# Altium schematic sheet 243-436_Manette-ESP32.SchDoc(243-436_Manette-ESP32)
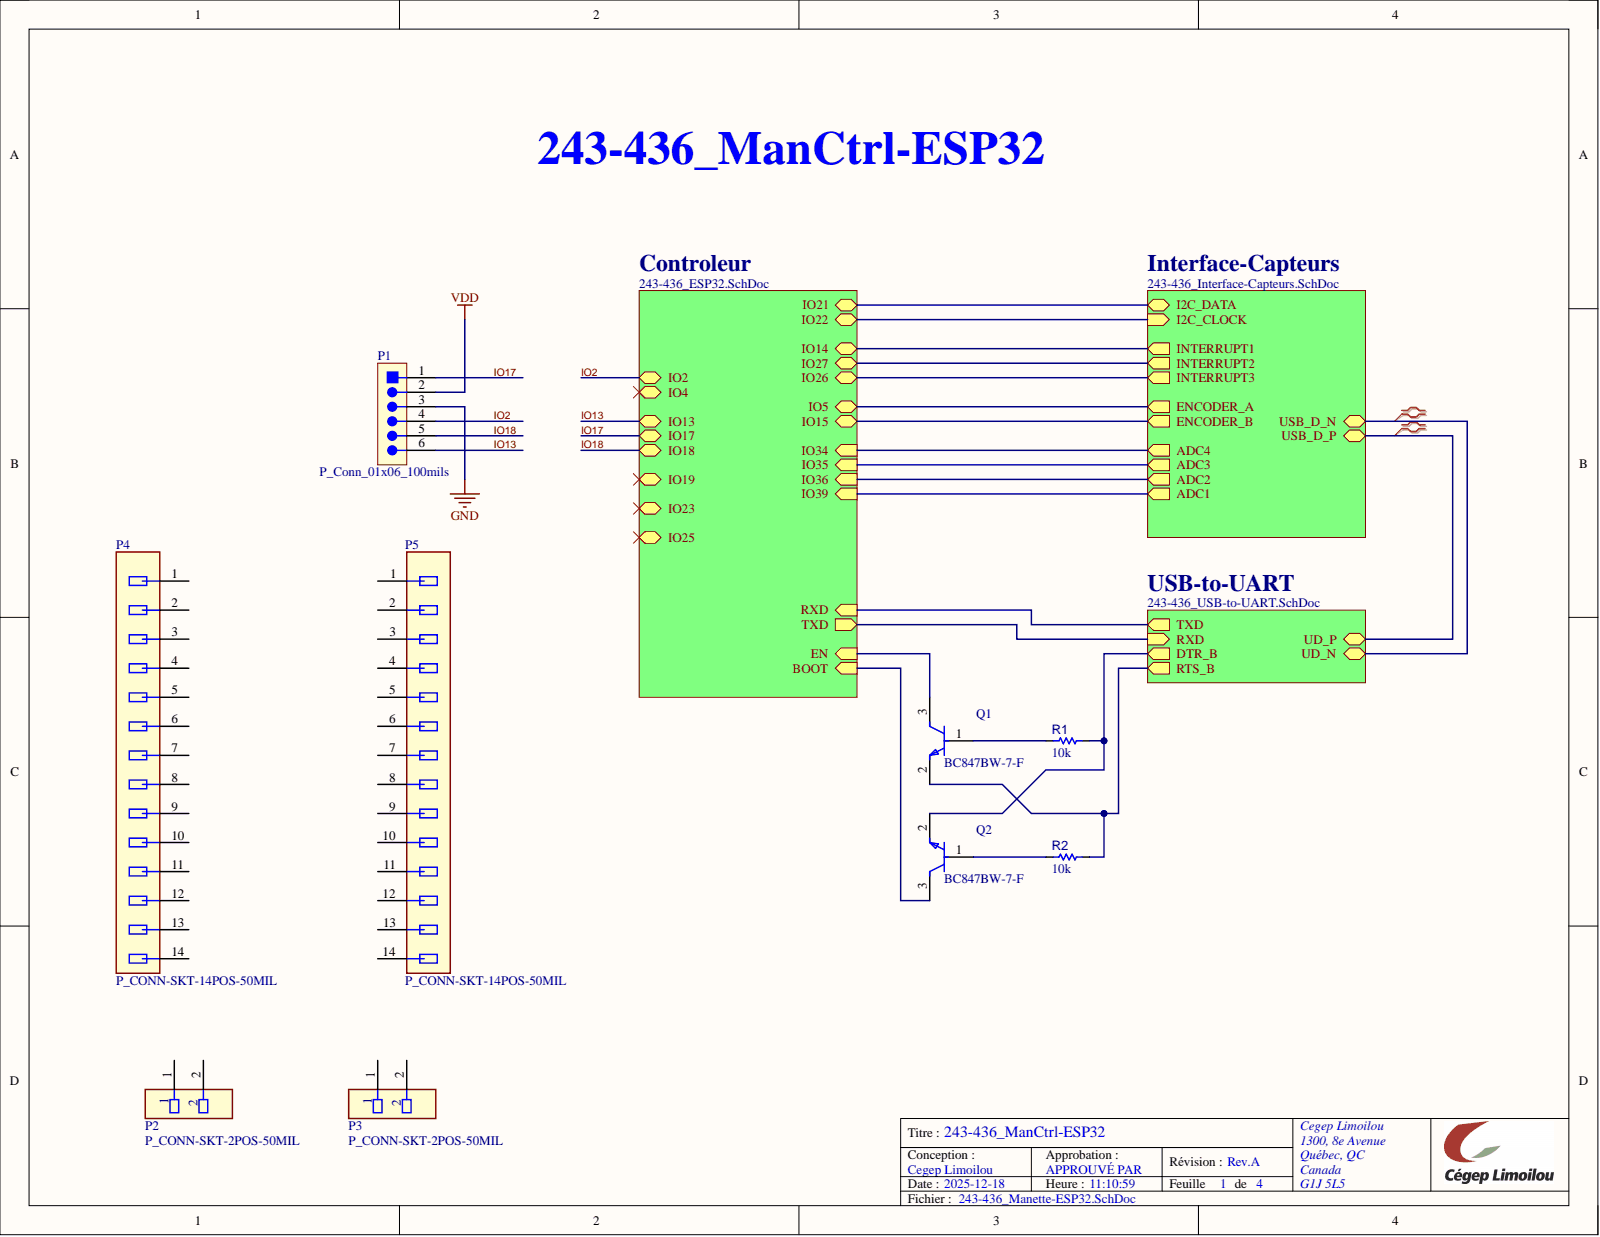
[diagram p0: 243-436_Manette-ESP32.SchDoc]

## Parts
P1  P_Conn_01x06_100mils  [P_CONN_HDR_1ROW_6POS_100MIL]  (no BOM)
P2  P_CONN-SKT-2POS-50MIL  [P_CONN_SKT_1ROW_2POS_50MIL]
P3  P_CONN-SKT-2POS-50MIL  [P_CONN_SKT_1ROW_2POS_50MIL]
P4  P_CONN-SKT-14POS-50MIL  [P_CONN_SKT_1ROW_14POS_50MIL]
P5  P_CONN-SKT-14POS-50MIL  [P_CONN_SKT_1ROW_14POS_50MIL]
Q1  BC847BW-7-F  [FP-SOT323-MFG]
Q2  BC847BW-7-F  [FP-SOT323-MFG]
R1  value 10k  [R_1005[0402]]  (hidden comment: Res 10k 1% 0402)
R2  value 10k  [R_1005[0402]]  (hidden comment: Res 10k 1% 0402)

## Nets
GND: P1.3
VDD: P1.2
IO2: P1.4
IO13: P1.6
IO17: P1.1
IO18: P1.5
NetP2_1: P2.1
NetP2_2: P2.2
NetP3_1: P3.1
NetP3_2: P3.2
NetP4_1: P4.1
NetP4_2: P4.2
NetP4_3: P4.3
NetP4_4: P4.4
NetP4_5: P4.5
NetP4_6: P4.6
NetP4_7: P4.7
NetP4_8: P4.8
NetP4_9: P4.9
NetP4_10: P4.10
NetP4_11: P4.11
NetP4_12: P4.12
NetP4_13: P4.13
NetP4_14: P4.14
NetP5_1: P5.1
NetP5_2: P5.2
NetP5_3: P5.3
NetP5_4: P5.4
NetP5_5: P5.5
NetP5_6: P5.6
NetP5_7: P5.7
NetP5_8: P5.8
NetP5_9: P5.9
NetP5_10: P5.10
NetP5_11: P5.11
NetP5_12: P5.12
NetP5_13: P5.13
NetP5_14: P5.14
NetQ1_1: Q1.1 R1.2
NetQ1_2: Q1.2 R2.1
NetQ1_3: Q1.3
NetQ2_1: Q2.1 R2.2
NetQ2_2: Q2.2 R1.1
NetQ2_3: Q2.3
ADC1:
ADC2:
ADC3:
ADC4:
ENCODER_A:
ENCODER_B:
I2C_CLOCK:
I2C_DATA:
INTERRUPT1:
INTERRUPT2:
INTERRUPT3:
IO4:
IO19:
IO23:
IO25:
RXD:
UD_N:
UD_P:

## Library data of the parts
P1 = P_Conn_01x06_100mils: Conn. Header 6pos 100mils; Mounting Technology: Through-hole; Library Name: 243-336_MP_Manette.SCHLIB
P2 P3 = P_CONN-SKT-2POS-50MIL: CONN SOCKET 2POS 1.27mm SINGLE ROW TH; Manufacturer 1: Megastar; Manufacturer Part Number 1: ZX-PM1.27-1-2PU-Z; Component Kind: Standard; Library Name: 243-436_MP_Man-ESP32.SCHLIB; Manufacturer 2: Sullins; Mounting Technology: Through-hole; Supplier 1: LCSC; Supplier Part Number 1: C41370629
P4 P5 = P_CONN-SKT-14POS-50MIL: CONN SOCKET 14POS 1.27mm SINGLE ROW TH; Manufacturer 1: Megastar; Manufacturer Part Number 1: ZX-PM1.27-1-14PU-Z; Component Kind: Standard; Library Name: 243-436_MP_Man-ESP32.SCHLIB; Manufacturer 2: Sullins; Mounting Technology: Through-hole; Supplier 1: LCSC; Supplier Part Number 1: C41370641
Q1 Q2 = CMP-12798-000012-1: TRANS NPN 45V 0.1A SC70-3; Manufacturer 1: Diodes Inc; Component Kind: Standard; ComponentLink2Description: Datasheet; ComponentLink2URL: https://www.diodes.com/assets/Datasheets/ds30250.pdf; Library Name: Altium Content Vault; Manufacturer Part Number 1: BC847BW-7-F
R1 R2 = RES003: Resistor type 1; Manufacturer 1: Vishay; Manufacturer Part Number 1: CR0402F1002T1LF; Database Table Name: Res$; Max Working Voltage: 50V; Puissance: 1/16W; Component Kind: Standard; LibRef: RES003; Mounting Technology: SMT; Supplier 1: Digikey; Supplier Part Number 1: 541-10.0KLCT-ND; Tolerance: 1%; Library Name: TEP_243-Altium_DBLib.DbLib
